# Altium schematic sheet ICWSHLP2_Adaptor_2x2_Power.SchDoc(ICWSHLP2_Adaptor_2x2_Power)
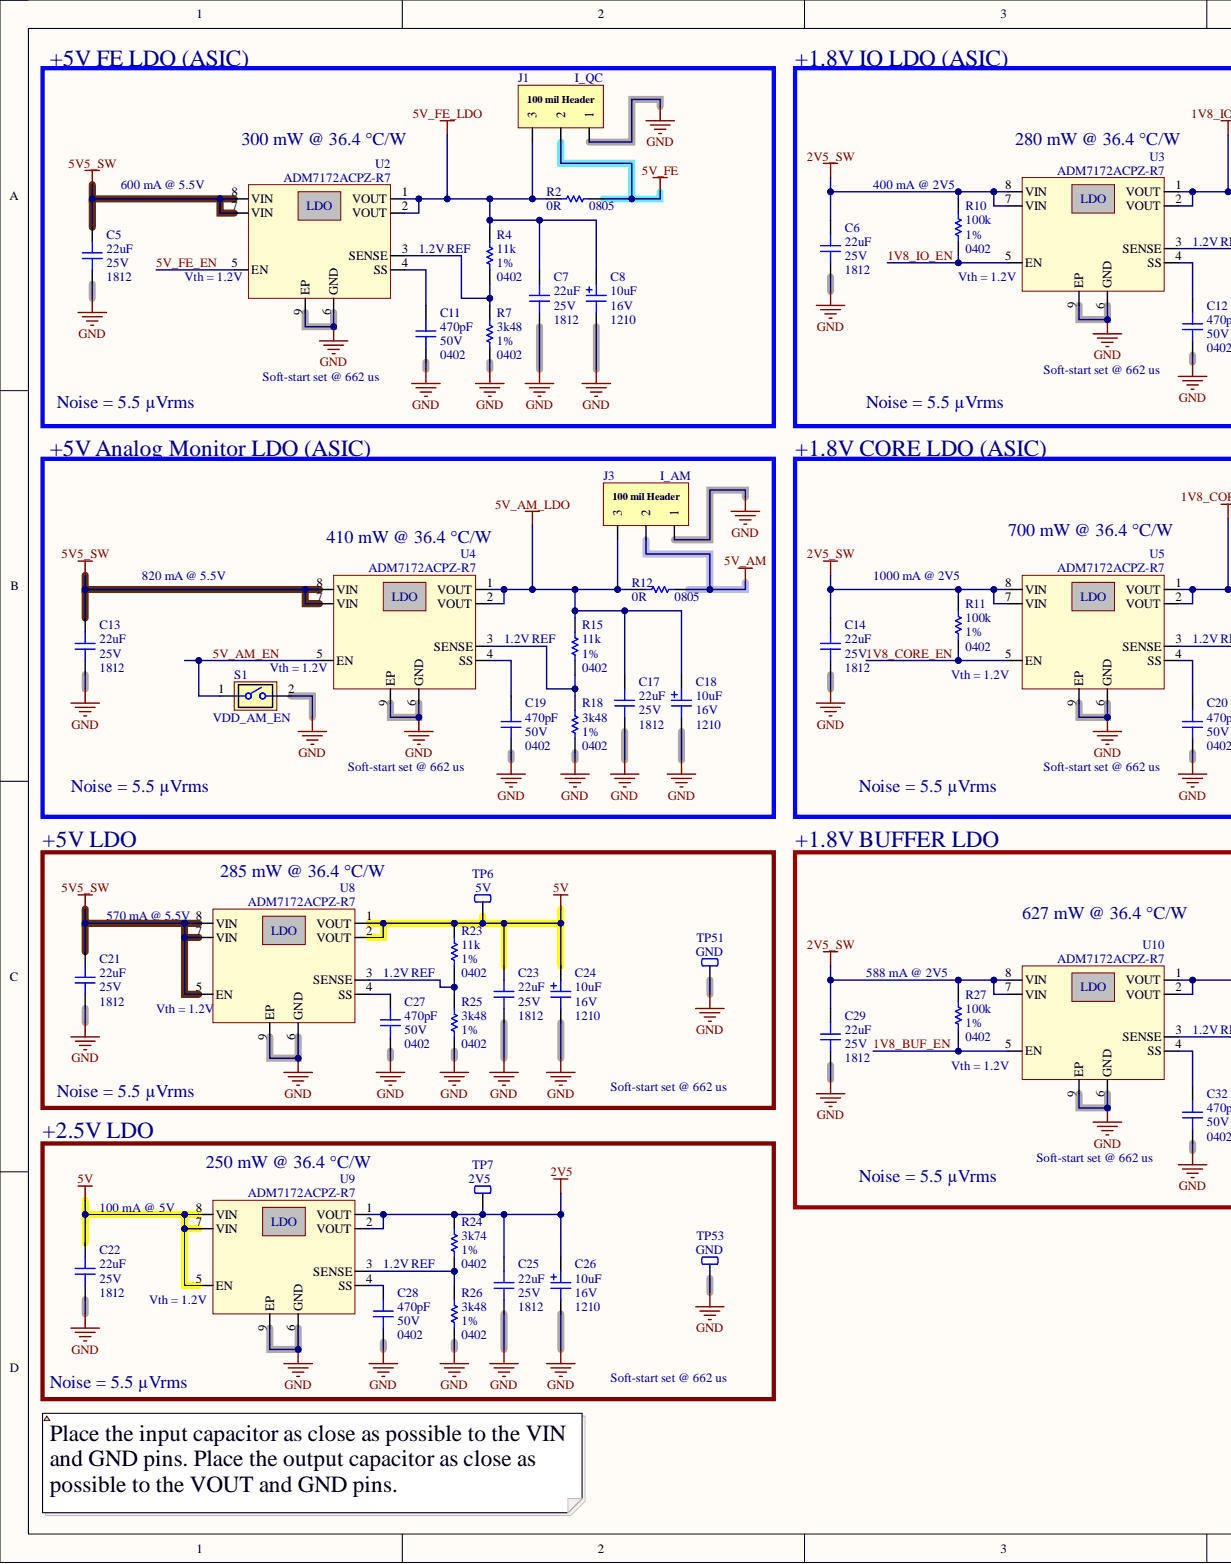
[diagram p0: ICWSHLP2_Adaptor_2x2_Power.SchDoc, left]
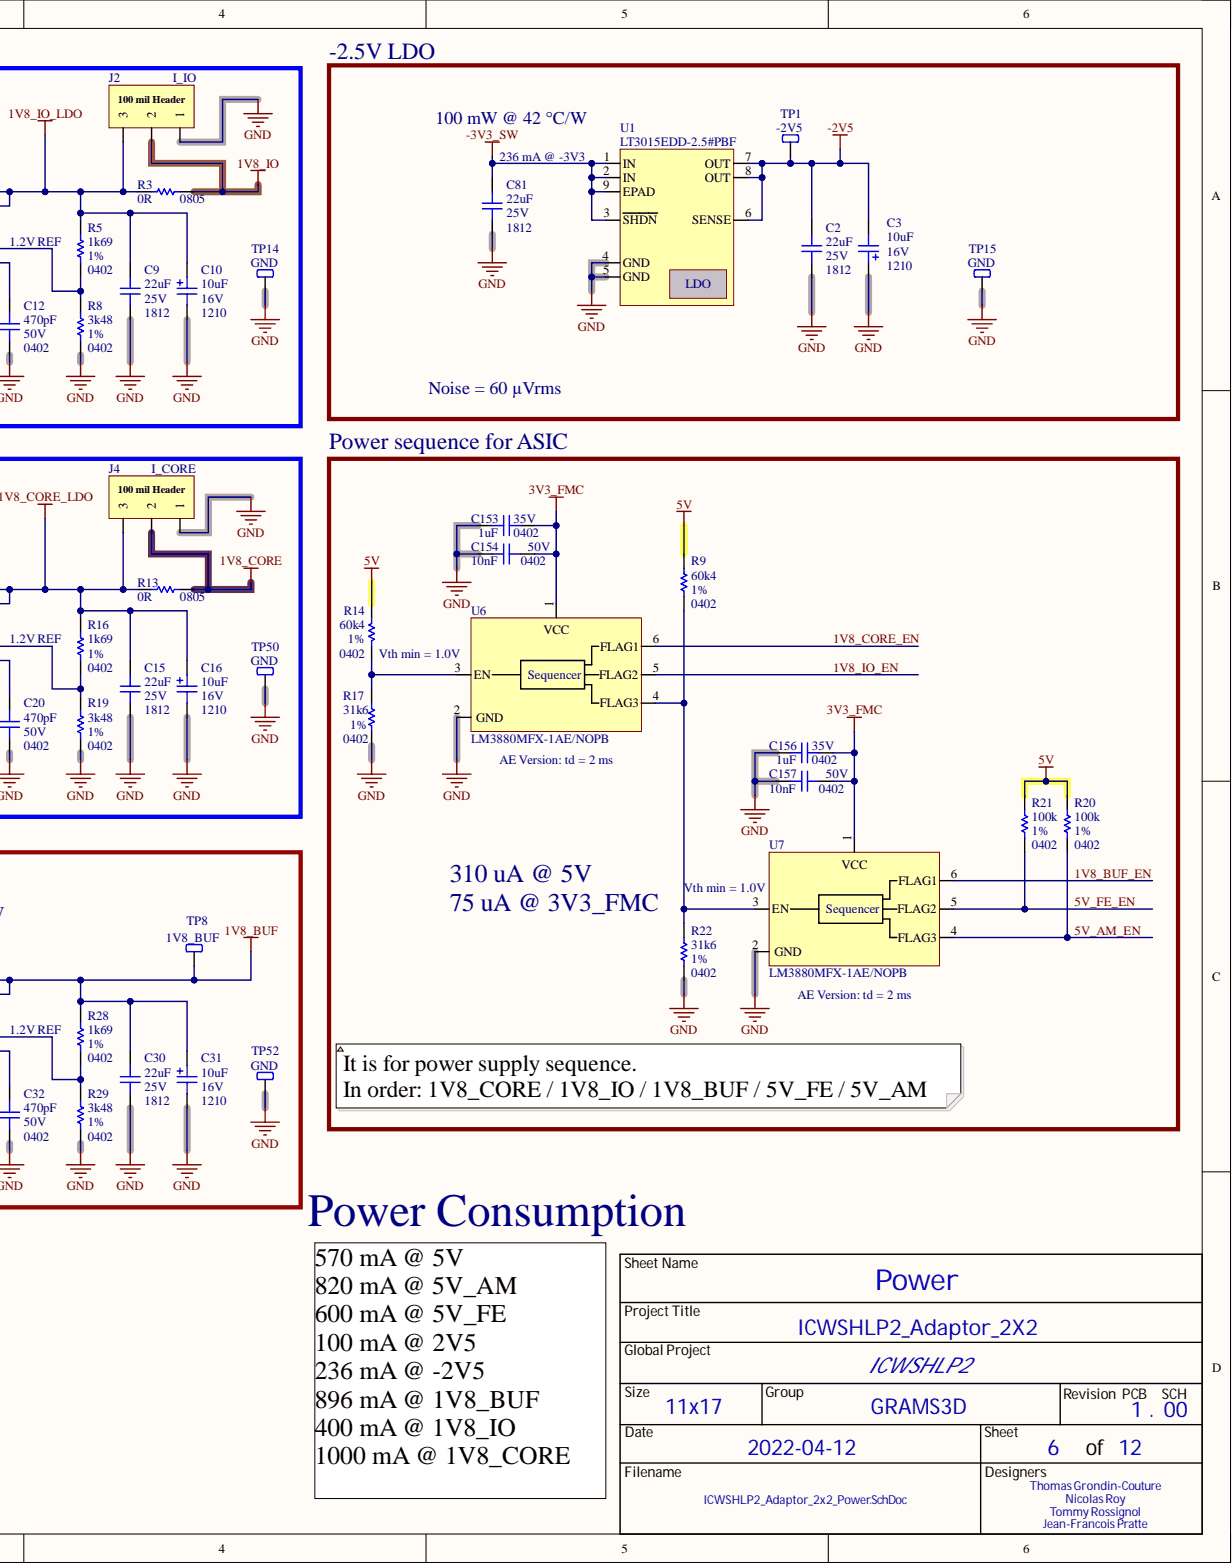
[diagram p1: ICWSHLP2_Adaptor_2x2_Power.SchDoc, right]

## Parts
C2  value 22uF  Package: 1812  Voltage Rating: 25V  [CAP_CER_1812]  (hidden comment: C4532X7R1E226M250KC)
C3  value 10uF  Package: 1210  Voltage Rating: 16V  [CAP_TAN_TPSB106M016R0500]  (hidden comment: TPSB106M016R0500)
C5  value 22uF  Package: 1812  Voltage Rating: 25V  [CAP_CER_1812]  (hidden comment: C4532X7R1E226M250KC)
C6  value 22uF  Package: 1812  Voltage Rating: 25V  [CAP_CER_1812]  (hidden comment: C4532X7R1E226M250KC)
C7  value 22uF  Package: 1812  Voltage Rating: 25V  [CAP_CER_1812]  (hidden comment: C4532X7R1E226M250KC)
C8  value 10uF  Package: 1210  Voltage Rating: 16V  [CAP_TAN_TPSB106M016R0500]  (hidden comment: TPSB106M016R0500)
C9  value 22uF  Package: 1812  Voltage Rating: 25V  [CAP_CER_1812]  (hidden comment: C4532X7R1E226M250KC)
C10  value 10uF  Package: 1210  Voltage Rating: 16V  [CAP_TAN_TPSB106M016R0500]  (hidden comment: TPSB106M016R0500)
C11  value 470pF  Package: 0402  Voltage Rating: 50V  [CAP_CER_0402]  (hidden comment: CC0402JRNPO9BN471)
C12  value 470pF  Package: 0402  Voltage Rating: 50V  [CAP_CER_0402]  (hidden comment: CC0402JRNPO9BN471)
C13  value 22uF  Package: 1812  Voltage Rating: 25V  [CAP_CER_1812]  (hidden comment: C4532X7R1E226M250KC)
C14  value 22uF  Package: 1812  Voltage Rating: 25V  [CAP_CER_1812]  (hidden comment: C4532X7R1E226M250KC)
C15  value 22uF  Package: 1812  Voltage Rating: 25V  [CAP_CER_1812]  (hidden comment: C4532X7R1E226M250KC)
C16  value 10uF  Package: 1210  Voltage Rating: 16V  [CAP_TAN_TPSB106M016R0500]  (hidden comment: TPSB106M016R0500)
C17  value 22uF  Package: 1812  Voltage Rating: 25V  [CAP_CER_1812]  (hidden comment: C4532X7R1E226M250KC)
C18  value 10uF  Package: 1210  Voltage Rating: 16V  [CAP_TAN_TPSB106M016R0500]  (hidden comment: TPSB106M016R0500)
C19  value 470pF  Package: 0402  Voltage Rating: 50V  [CAP_CER_0402]  (hidden comment: CC0402JRNPO9BN471)
C20  value 470pF  Package: 0402  Voltage Rating: 50V  [CAP_CER_0402]  (hidden comment: CC0402JRNPO9BN471)
C21  value 22uF  Package: 1812  Voltage Rating: 25V  [CAP_CER_1812]  (hidden comment: C4532X7R1E226M250KC)
C22  value 22uF  Package: 1812  Voltage Rating: 25V  [CAP_CER_1812]  (hidden comment: C4532X7R1E226M250KC)
C23  value 22uF  Package: 1812  Voltage Rating: 25V  [CAP_CER_1812]  (hidden comment: C4532X7R1E226M250KC)
C24  value 10uF  Package: 1210  Voltage Rating: 16V  [CAP_TAN_TPSB106M016R0500]  (hidden comment: TPSB106M016R0500)
C25  value 22uF  Package: 1812  Voltage Rating: 25V  [CAP_CER_1812]  (hidden comment: C4532X7R1E226M250KC)
C26  value 10uF  Package: 1210  Voltage Rating: 16V  [CAP_TAN_TPSB106M016R0500]  (hidden comment: TPSB106M016R0500)
C27  value 470pF  Package: 0402  Voltage Rating: 50V  [CAP_CER_0402]  (hidden comment: CC0402JRNPO9BN471)
C28  value 470pF  Package: 0402  Voltage Rating: 50V  [CAP_CER_0402]  (hidden comment: CC0402JRNPO9BN471)
C29  value 22uF  Package: 1812  Voltage Rating: 25V  [CAP_CER_1812]  (hidden comment: C4532X7R1E226M250KC)
C30  value 22uF  Package: 1812  Voltage Rating: 25V  [CAP_CER_1812]  (hidden comment: C4532X7R1E226M250KC)
C31  value 10uF  Package: 1210  Voltage Rating: 16V  [CAP_TAN_TPSB106M016R0500]  (hidden comment: TPSB106M016R0500)
C32  value 470pF  Package: 0402  Voltage Rating: 50V  [CAP_CER_0402]  (hidden comment: CC0402JRNPO9BN471)
C81  value 22uF  Package: 1812  Voltage Rating: 25V  [CAP_CER_1812]  (hidden comment: C4532X7R1E226M250KC)
C153  value 1uF  Package: 0402  Voltage Rating: 35V  [CAP_CER_0402]  (hidden comment: CL05A105KL5NRNC)
C154  value 10nF  Package: 0402  Voltage Rating: 50V  [CAP_CER_0402]  (hidden comment: GRM155R71H103KA88D)
C156  value 1uF  Package: 0402  Voltage Rating: 35V  [CAP_CER_0402]  (hidden comment: CL05A105KL5NRNC)
C157  value 10nF  Package: 0402  Voltage Rating: 50V  [CAP_CER_0402]  (hidden comment: GRM155R71H103KA88D)
J1  I_QC  [CON_HDR_M20-9990346]
J2  I_IO  [CON_HDR_M20-9990346]
J3  I_AM  [CON_HDR_M20-9990346]
J4  I_CORE  [CON_HDR_M20-9990346]
R2  value 0R  Package: 0805  [RES_0805]  (hidden comment: RC0805JR-070RL)
R3  value 0R  Package: 0805  [RES_0805]  (hidden comment: RC0805JR-070RL)
R4  value 11k  Package: 0402  Tolerance: 1%  [RES_0402]  (hidden comment: RC0402FR-0711KL)
R5  value 1k69  Package: 0402  Tolerance: 1%  [RES_0402]  (hidden comment: RC0402FR-071K69L)
R7  value 3k48  Package: 0402  Tolerance: 1%  [RES_0402]  (hidden comment: RC0402FR-073K48L)
R8  value 3k48  Package: 0402  Tolerance: 1%  [RES_0402]  (hidden comment: RC0402FR-073K48L)
R9  value 60k4  Package: 0402  Tolerance: 1%  [RES_0402]  (hidden comment: RC0402FR-0760K4L)
R10  value 100k  Package: 0402  Tolerance: 1%  [RES_0402]  (hidden comment: RC0402FR-07100KL)
R11  value 100k  Package: 0402  Tolerance: 1%  [RES_0402]  (hidden comment: RC0402FR-07100KL)
R12  value 0R  Package: 0805  [RES_0805]  (hidden comment: RC0805JR-070RL)
R13  value 0R  Package: 0805  [RES_0805]  (hidden comment: RC0805JR-070RL)
R14  value 60k4  Package: 0402  Tolerance: 1%  [RES_0402]  (hidden comment: RC0402FR-0760K4L)
R15  value 11k  Package: 0402  Tolerance: 1%  [RES_0402]  (hidden comment: RC0402FR-0711KL)
R16  value 1k69  Package: 0402  Tolerance: 1%  [RES_0402]  (hidden comment: RC0402FR-071K69L)
R17  value 31k6  Package: 0402  Tolerance: 1%  [RES_0402]  (hidden comment: RC0402FR-0731K6L)
R18  value 3k48  Package: 0402  Tolerance: 1%  [RES_0402]  (hidden comment: RC0402FR-073K48L)
R19  value 3k48  Package: 0402  Tolerance: 1%  [RES_0402]  (hidden comment: RC0402FR-073K48L)
R20  value 100k  Package: 0402  Tolerance: 1%  [RES_0402]  (hidden comment: RC0402FR-07100KL)
R21  value 100k  Package: 0402  Tolerance: 1%  [RES_0402]  (hidden comment: RC0402FR-07100KL)
R22  value 31k6  Package: 0402  Tolerance: 1%  [RES_0402]  (hidden comment: RC0402FR-0731K6L)
R23  value 11k  Package: 0402  Tolerance: 1%  [RES_0402]  (hidden comment: RC0402FR-0711KL)
R24  value 3k74  Package: 0402  Tolerance: 1%  [RES_0402]  (hidden comment: RC0402FR-073K74L)
R25  value 3k48  Package: 0402  Tolerance: 1%  [RES_0402]  (hidden comment: RC0402FR-073K48L)
R26  value 3k48  Package: 0402  Tolerance: 1%  [RES_0402]  (hidden comment: RC0402FR-073K48L)
R27  value 100k  Package: 0402  Tolerance: 1%  [RES_0402]  (hidden comment: RC0402FR-07100KL)
R28  value 1k69  Package: 0402  Tolerance: 1%  [RES_0402]  (hidden comment: RC0402FR-071K69L)
R29  value 3k48  Package: 0402  Tolerance: 1%  [RES_0402]  (hidden comment: RC0402FR-073K48L)
S1  VDD_AM_EN  [SWI_SPST_CHS-01TA]
TP1  -2V5  [TPO_SMD_RCU-0C]
TP6  5V  [TPO_SMD_RCU-0C]
TP7  2V5  [TPO_SMD_RCU-0C]
TP8  1V8_BUF  [TPO_SMD_RCU-0C]
TP14  GND  [TPO_SMD_RCU-0C]
TP15  GND  [TPO_SMD_RCU-0C]
TP50  GND  [TPO_SMD_RCU-0C]
TP51  GND  [TPO_SMD_RCU-0C]
TP52  GND  [TPO_SMD_RCU-0C]
TP53  GND  [TPO_SMD_RCU-0C]
U1  LT3015EDD-2.5#PBF  [REG_LDO_LT3015EDD-2.5#PBF]
U2  ADM7172ACPZ-R7  [REG_LDO_ADM7172ACPZ-R7]
U3  ADM7172ACPZ-R7  [REG_LDO_ADM7172ACPZ-R7]
U4  ADM7172ACPZ-R7  [REG_LDO_ADM7172ACPZ-R7]
U5  ADM7172ACPZ-R7  [REG_LDO_ADM7172ACPZ-R7]
U6  LM3880MFX-1AE/NOPB  [POW_LM3880MFX-1AE/NOPB]
U7  LM3880MFX-1AE/NOPB  [POW_LM3880MFX-1AE/NOPB]
U8  ADM7172ACPZ-R7  [REG_LDO_ADM7172ACPZ-R7]
U9  ADM7172ACPZ-R7  [REG_LDO_ADM7172ACPZ-R7]
U10  ADM7172ACPZ-R7  [REG_LDO_ADM7172ACPZ-R7]

## Nets
1V8_BUF: C30.2 C31.1 R28.2 TP8.1 U10.1(VOUT) U10.2(VOUT)
1V8_CORE: J4.2 R13.1
1V8_CORE_LDO: C15.2 C16.1 J4.3 R13.2 R16.2 U5.1(VOUT) U5.2(VOUT)
1V8_IO: J2.2 R3.1
1V8_IO_LDO: C9.2 C10.1 J2.3 R3.2 R5.2 U3.1(VOUT) U3.2(VOUT)
2V5: C25.2 C26.1 R24.2 TP7.1 U9.1(VOUT) U9.2(VOUT)
2V5_SW: C6.2 C14.2 C29.2 R10.2 R11.2 R27.2 U3.7(VIN) U3.8(VIN) U5.7(VIN) U5.8(VIN) U10.7(VIN) U10.8(VIN)
3V3_FMC: C153.1 C154.1 C156.1 C157.1 U6.1 U7.1
5V: C22.2 C23.2 C24.1 R9.2 R14.2 R20.2 R21.2 R23.2 TP6.1 U8.1(VOUT) U8.2(VOUT) U9.5(EN) U9.7(VIN) U9.8(VIN)
5V5_SW: C5.2 C13.2 C21.2 U2.7(VIN) U2.8(VIN) U4.7(VIN) U4.8(VIN) U8.5(EN) U8.7(VIN) U8.8(VIN)
5V_AM: J3.2 R12.1
5V_AM_LDO: C17.2 C18.1 J3.3 R12.2 R15.2 U4.1(VOUT) U4.2(VOUT)
5V_FE: J1.2 R2.1
5V_FE_LDO: C7.2 C8.1 J1.3 R2.2 R4.2 U2.1(VOUT) U2.2(VOUT)
-2V5: C2.2 C3.2 TP1.1 U1.6(SENSE) U1.7(OUT) U1.8(OUT)
-3V3_SW: C81.2 U1.1(IN) U1.2(IN) U1.3(SHDN) U1.9(EPAD)
GND: C2.1 C3.1 C5.1 C6.1 C7.1 C8.2 C9.1 C10.2 C11.1 C12.1 C13.1 C14.1 C15.1 C16.2 C17.1 C18.2 C19.1 C20.1 C21.1 C22.1 C23.1 C24.2 C25.1 C26.2 C27.1 C28.1 C29.1 C30.1 C31.2 C32.1 C81.1 C153.2 C154.2 C156.2 C157.2 J1.1 J2.1 J3.1 J4.1 R7.1 R8.1 R17.1 R18.1 R19.1 R22.1 R25.1 R26.1 R29.1 S1.2 TP14.1 TP15.1 TP50.1 TP51.1 TP52.1 TP53.1 U1.4(GND) U1.5(GND) U2.6(GND) U2.9(EP) U3.6(GND) U3.9(EP) U4.6(GND) U4.9(EP) U5.6(GND) U5.9(EP) U6.2(GND) U7.2(GND) U8.6(GND) U8.9(EP) U9.6(GND) U9.9(EP) U10.6(GND) U10.9(EP)
1V8_BUF_EN: R27.1 U7.6(FLAG1) U10.5(EN)
1V8_CORE_EN: R11.1 U5.5(EN) U6.6(FLAG1)
1V8_IO_EN: R10.1 U3.5(EN) U6.5(FLAG2)
5V_AM_EN: R20.1 S1.1 U4.5(EN) U7.4(FLAG3)
5V_FE_EN: R21.1 U2.5(EN) U7.5(FLAG2)
NetC11_2: C11.2 U2.4(SS)
NetC12_2: C12.2 U3.4(SS)
NetC19_2: C19.2 U4.4(SS)
NetC20_2: C20.2 U5.4(SS)
NetC27_2: C27.2 U8.4(SS)
NetC28_2: C28.2 U9.4(SS)
NetC32_2: C32.2 U10.4(SS)
NetR4_1: R4.1 R7.2 U2.3(SENSE)
NetR5_1: R5.1 R8.2 U3.3(SENSE)
NetR9_1: R9.1 R22.2 U6.4(FLAG3) U7.3(EN)
NetR14_1: R14.1 R17.2 U6.3(EN)
NetR15_1: R15.1 R18.2 U4.3(SENSE)
NetR16_1: R16.1 R19.2 U5.3(SENSE)
NetR23_1: R23.1 R25.2 U8.3(SENSE)
NetR24_1: R24.1 R26.2 U9.3(SENSE)
NetR28_1: R28.1 R29.2 U10.3(SENSE)

## Library data of the parts
C2 C5-C7 C9 C13-C15 C17 C21-C23 C25 C29 C30 C81 = CAP_CER_C4532X7R1E226M250KC: CAP - CER 22uF ±20% 25V X7R - 1812; Manufacturer #1: TDK Corporation; Cryo: UNKNOWN; GRAMS Lib Spec: 2.8; Note: DC bias = 21.5uF@5V, 17.6uF@10V; Polarized: No; Assembly Type: SMD; Component Kind: Standard; HelpURL: https://product.tdk.com/info/en/documents/chara_sheet/C4532X7R1E226M250KC.pdf; Library Name: GRAMS_MASTER_LIB.IntLib; Magnetic Material: Unknown; Part Number #1: C4532X7R1E226M250KC; Supplier 1: Digi-Key; Supplier Part Number 1: 445-1607-1-ND; Temperature: -55°C ~ 125°C; Tolerance: 20%; CreatedBy/Date: Keven Deslandes - 2018/12/18; VerifiedBy: Caroline Paulin - 24 janvier 2019
C3 C8 C10 C16 C18 C24 C26 C31 = CAP_TAN_TPSB106M016R0500: CAP - TANTALUM 10UF 16V 20% - 1210; Manufacturer #1: AVX Corporation; Cryo: UNKNOWN; GRAMS Lib Spec: 2.8; Polarized: Yes; Assembly Type: SMD; Component Kind: Standard; HelpURL: datasheets.avx.com/TPS.pdf; Library Name: GRAMS_MASTER_LIB.IntLib; Part Number #1: TPSB106M016R0500; Supplier 1: Digi-Key; Supplier Part Number 1: 478-9052-1-ND; Temperature: -55°C ~ 125°C; Tolerance: 20%; CreatedBy/Date: Keven Deslandes; VerifiedBy: Caroline Paulin - 2019
C11 C12 C19 C20 C27 C28 C32 = CAP_CER_CC0402JRNPO9BN471: CAP - CER 470pF ±5% 50V NP0/C0G - 0402; Manufacturer #1: Yageo; Cryo: UNKNOWN; GRAMS Lib Spec: 2.8; Polarized: No; Assembly Type: SMD; Component Kind: Standard; HelpURL: http://www.yageo.com/documents/recent/UPY-GP_NP0_16V-to-50V_16.pdf; Library Name: GRAMS_MASTER_LIB.IntLib; Magnetic Material: Unknown; Part Number #1: CC0402JRNPO9BN471; Supplier 1: Digi-Key; Supplier Part Number 1: 311-1671-1-ND; Temperature: -55°C ~ 125°C; Tolerance: 5%; CreatedBy/Date: Keven Deslandes - 2018/12/18; VerifiedBy: Caroline Paulin - 23 janv 2019
C153 C156 = CAP_CER_CL05A105KL5NRNC: CAP - CER 1uF 10% 35V X5R - 0402; Manufacturer #1: Samsung Electro-Mechanics; Cryo: UNKNOWN; GRAMS Lib Spec: 2.8; Polarized: NO; Assembly Type: SMD; Component Kind: Standard; HelpURL: http://www.samsungsem.com/kr/support/product-search/mlcc/__icsFiles/afieldfile/2018/06/19/CL05A105KL5NRNC.pdf; Library Name: GRAMS_MASTER_LIB.IntLib; Magnetic Material: Unknown; Part Number #1: CL05A105KL5NRNC; Supplier 1: Digi-Key; Supplier Part Number 1: 1276-6796-1-ND; Temperature: -55°C ~ 85°C; Tolerance: 10%; CreatedBy/Date: Nicolas Roy 2018-10-03; VerifiedBy: Caroline Paulin - 18 janv 2019
C154 C157 = CAP_CER_GRM155R71H103KA88D: CAP - CER 10nF 10% 50V X7R - 0402; Manufacturer #1: Murata Electronics North America; Cryo: UNKNOWN; GRAMS Lib Spec: 2.8; Polarized: No; Assembly Type: SMD; Component Kind: Standard; HelpURL: https://search.murata.co.jp/Ceramy/image/img/A01X/G101/ENG/GRM155R71H103KA88-01.pdf; Library Name: GRAMS_MASTER_LIB.IntLib; Magnetic Material: Unknown; Part Number #1: GRM155R71H103KA88D; Supplier 1: Digi-Key; Supplier Part Number 1: 490-4516-1-ND; Temperature: -55°C ~ 125°C; Tolerance: 10%; CreatedBy/Date: C.Paulin - 19/06/2019; VerifiedBy: Nicolas V. (2019-07-04)
J1-J4 = CON_HDR_M20-9990346: CON - HDR 100 MIL 3 POS - TH; Manufacturer #1: Harwin Inc.; Cryo: UNKNOWN; Current Rating: 3A per contact; GRAMS Lib Spec: 2.8; Magnetic Material: No; Assembly Type: TH; Component Kind: Standard; HelpURL: https://cdn.harwin.com/pdfs/M20-999.pdf; Library Name: GRAMS_MASTER_LIB.IntLib; Manufacturer #2: Harwin Inc.; Package: TH; Part Number #1: M20-9990346; Part Number #2: M20-9994046; Polarized: No; Power Max: -; Supplier 1: Digi-Key; Supplier Part Number 1: 952-2264-ND; Temperature: -40°C ~ 105°C; Tolerance: -; Value: -; Voltage Rating: -; CreatedBy/Date: L-P Gauthier/2018/05/11; VerifiedBy: Caroline Paulin - 2 oct 2018
R2 R3 R12 R13 = RES_RC0805JR-070RL: RES - 0 OHM 1/8W - 0805; Manufacturer #1: Yageo; Cryo: UNKNOWN; Current Rating: 2A; GRAMS Lib Spec: 2.8; Polarized: NO; Assembly Type: SMD; Component Kind: Standard; HelpURL: http://www.yageo.com/documents/recent/PYu-RC_Group_51_RoHS_L_10.pdf; Library Name: GRAMS_MASTER_LIB.IntLib; Magnetic Material: Unknown; Part Number #1: RC0805JR-070RL; Power Max: 1/8W; Supplier 1: Digi-Key; Supplier Part Number 1: 311-0.0ARCT-ND; Temperature: -55°C ~ 155°C; Voltage Rating: -; CreatedBy/Date: Nicolas Roy/03 dec. 2018; VerifiedBy: Caroline Paulin - 8 janv 2019
R4 R15 R23 = RES_RC0402FR-0711KL: RES - 11K 1% 1/16W - 0402; Manufacturer #1: Yageo; Cryo: UNKNOWN; GRAMS Lib Spec: 2.8; Polarized: No; Voltage Rating: 50V; Assembly Type: SMD; Component Kind: Standard; HelpURL: http://www.yageo.com/documents/recent/PYu-RC_Group_51_RoHS_L_9.pdf; Library Name: GRAMS_MASTER_LIB.IntLib; Magnetic Material: Unknown; Part Number #1: RC0402FR-0711KL; Power Max: 1/16W; Supplier 1: Digi-Key; Supplier Part Number 1: 311-11.0KLRCT-ND; Temperature: -55°C ~ 155°C; CreatedBy/Date: Keven Deslandes 2018-10-15; VerifiedBy: Carolilne Paulin - 18/12/2018
R5 R16 R28 = RES_RC0402FR-071K69L: RES - 1.69K 1% 1/16W - 0402; Manufacturer #1: Yageo; Cryo: UNKNOWN; GRAMS Lib Spec: 2.8; Polarized: No; Voltage Rating: 50V; Assembly Type: SMD; Component Kind: Standard; HelpURL: http://www.yageo.com/documents/recent/PYu-RC_Group_51_RoHS_L_9.pdf; Library Name: GRAMS_MASTER_LIB.IntLib; Magnetic Material: Unknown; Part Number #1: RC0402FR-071K69L; Power Max: 1/16W; Supplier 1: Digi-Key; Supplier Part Number 1: YAG3044CT-ND; Temperature: -55°C ~ 155°C; CreatedBy/Date: Keven Deslandes 2018-10-15; VerifiedBy: Caroline Paulin - 18/12/2018
R7 R8 R18 R19 R25 R26 R29 = RES_RC0402FR-073K48L: RES - 3.48K 1% 1/16W - 0402; Manufacturer #1: Yageo; Cryo: UNKNOWN; GRAMS Lib Spec: 2.8; Polarized: No; Voltage Rating: 50V; Assembly Type: SMD; Component Kind: Standard; HelpURL: http://www.yageo.com/documents/recent/PYu-RC_Group_51_RoHS_L_10.pdf; Library Name: GRAMS_MASTER_LIB.IntLib; Magnetic Material: Unknown; Part Number #1: RC0402FR-073K48L; Power Max: 1/16W; Supplier 1: Digi-Key; Supplier Part Number 1: YAG3148CT-ND; Temperature: -55°C ~ 155°C; CreatedBy/Date: Keven Deslandes - 2018-10-10; VerifiedBy: Caroline Paulin - 8 janv 2019
R9 R14 = RES_RC0402FR-0760K4L: RES - 60.4k 1% 1/16W - 0402; Manufacturer #1: Yageo; Cryo: UNKNOWN; GRAMS Lib Spec: 2.8c; Polarized: No; Voltage Rating: 50V; Assembly Type: SMD; Component Kind: Standard; HelpURL: https://www.yageo.com/upload/media/product/productsearch/datasheet/rchip/PYu-RC_Group_51_RoHS_L_10.pdf; Library Name: GRAMS_MASTER_LIB.IntLib; Magnetic Material: Unknown; Part Number #1: RC0402FR-0760K4L; Power Max: 1/16W; Supplier 1: Digi-Key; Supplier Part Number 1: 311-60.4KLRCT-ND; Temperature: -55°C ~ 155°C; CreatedBy/Date: Joël Lemay 2020-01-08; VerifiedBy: C.Paulin - 05/02/2020
R10 R11 R20 R21 R27 = RES_RC0402FR-07100KL: RES - 100K 1% 1/16W - 0402; Manufacturer #1: Yageo; Cryo: UNKNOWN; GRAMS Lib Spec: 2.8; Polarized: No; Voltage Rating: 50V; Assembly Type: SMD; Component Kind: Standard; HelpURL: http://www.yageo.com/documents/recent/PYu-RC_Group_51_RoHS_L_9.pdf; Library Name: GRAMS_MASTER_LIB.IntLib; Magnetic Material: Unknown; Part Number #1: RC0402FR-07100KL; Power Max: 1/16W; Supplier 1: Digi-Key; Supplier Part Number 1: 311-100KLRCT-ND; Temperature: -55°C ~ 155°C; CreatedBy/Date: Keven Deslandes 2018-10-19; VerifiedBy: Caroline Paulin - 18/12/2018
R17 R22 = RES_RC0402FR-0731K6L: RES - 31.6K 1% 1/16W - 0402; Manufacturer #1: Yageo; Cryo: UNKNOWN; GRAMS Lib Spec: 2.8; Polarized: No; Voltage Rating: 50V; Assembly Type: SMD; Component Kind: Standard; HelpURL: http://www.yageo.com/documents/recent/PYu-RC_Group_51_RoHS_L_10.pdf; Library Name: GRAMS_MASTER_LIB.IntLib; Magnetic Material: Unknown; Part Number #1: RC0402FR-0731K6L; Power Max: 1/16W; Supplier 1: Digi-Key; Supplier Part Number 1: YAG3115CT-ND; Temperature: -55°C ~ 155°C; CreatedBy/Date: Keven Deslandes 2018-10-16; VerifiedBy: Caroline Paulin - 8 janv 2019
R24 = RES_RC0402FR-073K74L: RES - 3.74K 1% 1/16W - 0402; Manufacturer #1: Yageo; Cryo: UNKNOWN; GRAMS Lib Spec: 2.8; Polarized: No; Voltage Rating: 50V; Assembly Type: SMD; Component Kind: Standard; HelpURL: http://www.yageo.com/documents/recent/PYu-RC_Group_51_RoHS_L_10.pdf; Library Name: GRAMS_MASTER_LIB.IntLib; Magnetic Material: Unknown; Part Number #1: RC0402FR-073K74L; Power Max: 1/16W; Supplier 1: Digi-Key; Supplier Part Number 1: 311-3.74KLRCT-ND; Temperature: -55°C ~ 155°C; CreatedBy/Date: Keven Deslandes - 2018-10-10; VerifiedBy: Caroline Paulin - 8 janv 2019
S1 = SWI_SPST_CHS-01TA: SWI - SWITCH SLIDE DIP SPST 100MA 6V - SMD; Manufacturer #1: Nidec Copal Electronics; Cryo: UNKNOWN; GRAMS Lib Spec: 2.8; Polarized: Yes; Value: DIP Switch; Voltage rating: 6VDC; Assembly Type: SMD; Component Kind: Standard; Current Rating: 100mA; HelpURL: https://www.nidec-copal-electronics.com/e/catalog/switch/chs.pdf; Library Name: GRAMS_MASTER_LIB.IntLib; Magnetic Material: UNKNOWN; Package: SMD; Part Number #1: CHS-01TA; Supplier 1: Digi-Key; Supplier Part Number 1: 563-1003-1-ND; Temperature: -40°C ~ 85°C; CreatedBy/Date: Olivier Bernard / 16/4/2018; VerifiedBy: Caroline Paulin / 10 juillet 2018
TP1 TP6-TP8 TP14 TP15 TP50-TP53 = TPO_SMD_RCU-0C: TPO - TEST POINT PROBE PAD - 0603; Manufacturer #1: TE Connectivity AMP Connectors; Part Number #1: RCU-0C; Cryo: UNKNOWN; GRAMS Lib Spec: 2.8; Polarized: No; Value: 1.6 mm x 0.8 mm; Assembly Type: SMD; Component Kind: Standard; HelpURL: http://www.te.com/commerce/DocumentDelivery/DDEController?Action=srchrtrv&DocNm=1773266&DocType=DS&DocLang=English; Library Name: GRAMS_MASTER_LIB.IntLib; Magnetic Material: UNKNOWN; Package: 0603; Supplier 1: Digi-Key; Supplier Part Number 1: A106145CT-ND; CreatedBy/Date: Olivier Bernard / 20/5/2018; VerifiedBy: Caroline Paulin / 6 juillet 2018
U1 = REG_LDO_LT3015EDD-2.5#PBF: REG - LINEAR NEG FIXED -2.5V 1.5A - 8DFN; Manufacturer #1: Analog Devices Inc.; Cryo: UNKNOWN; GRAMS Lib Spec: 3.2; Polarized: YES; Solder Paste Type: TYPE 6; Stencil Thickness (Recommended): Max 4 MILS; Value: Vout : –2.5V; Voltage Rating: Vin : –1.8V ~ –30V; Assembly Type: SMD; Component Kind: Standard; Current Rating: 1.5A; HelpURL: https://www.analog.com/media/en/technical-documentation/data-sheets/3015fb.pdf; Library Name: GRAMS_MASTER_LIB_SCH.SchLib; Magnetic Material: UNKNOWN; Package: 8DFN; Part Number #1: LT3015EDD-2.5#PBF; Supplier 1: Digi-Key; Supplier Part Number 1: LT3015EDD-2.5#PBF-ND; Temperature: -40°C ~ 125°C; CreatedBy/Date: Nicolas Roy - 25/04/2022; VerifiedBy: C.Paulin - 2022/04/26
U2-U5 U8-U10 = REG_LDO_ADM7172ACPZ-R7: REG - LDO POS ADJ (1.2V to Vin-Vdo) 2A - 8-WFDFN; Manufacturer #1: Analog Devices; GRAMS Lib Spec: 2.8; Magnetic Material: Unknown; Polarized: Yes; Tolerance: Vref 1.5%; Value: Vout 1.2V~Vin-Vdo; Voltage Rating: Vin=2.3V~6.5V; Assembly Type: SMD; Component Kind: Standard; Current Rating: 2A; HelpURL: https://www.analog.com/media/en/technical-documentation/data-sheets/ADM7172.pdf; Library Name: GRAMS_MASTER_LIB.IntLib; Package: 8-WFDFN; Part Number #1: ADM7172ACPZ-R7; Supplier 1: Digi-Key; Supplier Part Number 1: ADM7172ACPZ-R7CT-ND; Temperature: -40°C ~ 125°C; CreatedBy/Date: Nicolas Roy - 2019/04/03; VerifiedBy: Nicolas V. (2019-04-11)
U6 U7 = POW_LM3880MFX-1AE/NOPB: POW - Power Sequencer, Three-Rail Simple Power Sequencer - SOT-23-6; Manufacturer #1: Texas Instruments; Cryo: UNKNOWN; GRAMS Lib Spec: 2.8; Polarized: Yes; Voltage Rating: 2.7V ~ 5.5V; Assembly Type: SMD; Component Kind: Standard; Current Rating: -; HelpURL: http://www.ti.com/lit/ds/symlink/lm3880.pdf; Library Name: GRAMS_MASTER_LIB.IntLib; Magnetic Material: Unknown; Package: SOT-23-6; Part Number #1: LM3880MFX-1AE/NOPB; Supplier 1: Digi-Key; Supplier Part Number 1: 296-48921-1-ND; Temperature: -40°C ~ 125°C; CreatedBy/Date: Nicolas Roy - 2018/12/03; VerifiedBy: Caroline Paulin - 14 dec 2018
